# Altium schematic sheet ГРЛМ.468381.003 Э3.SchDoc
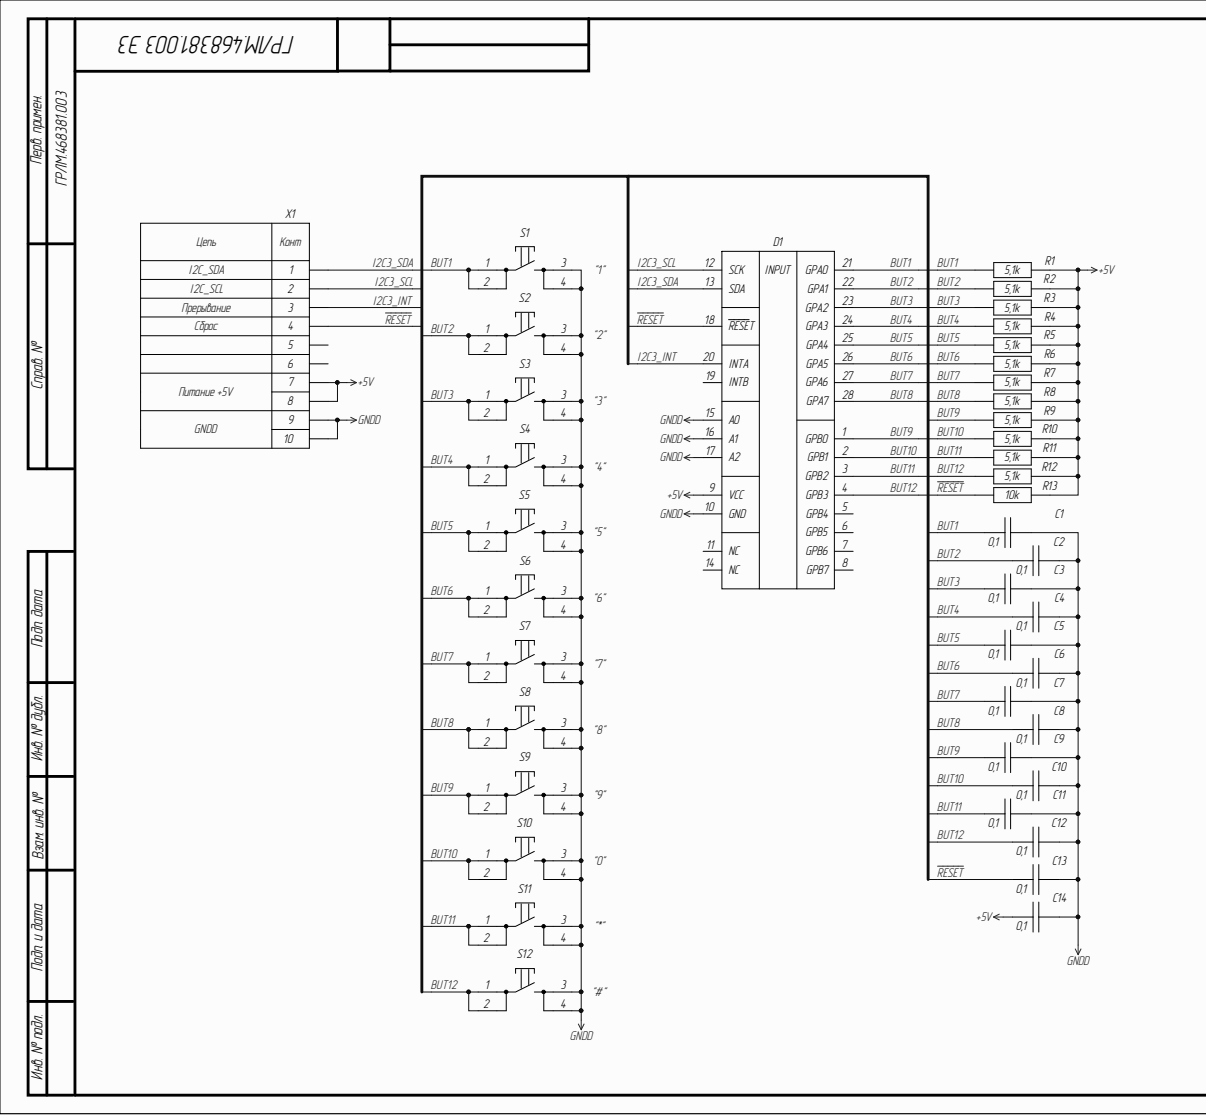
[diagram p0: ГРЛМ.468381.003 Э3.SchDoc, left]
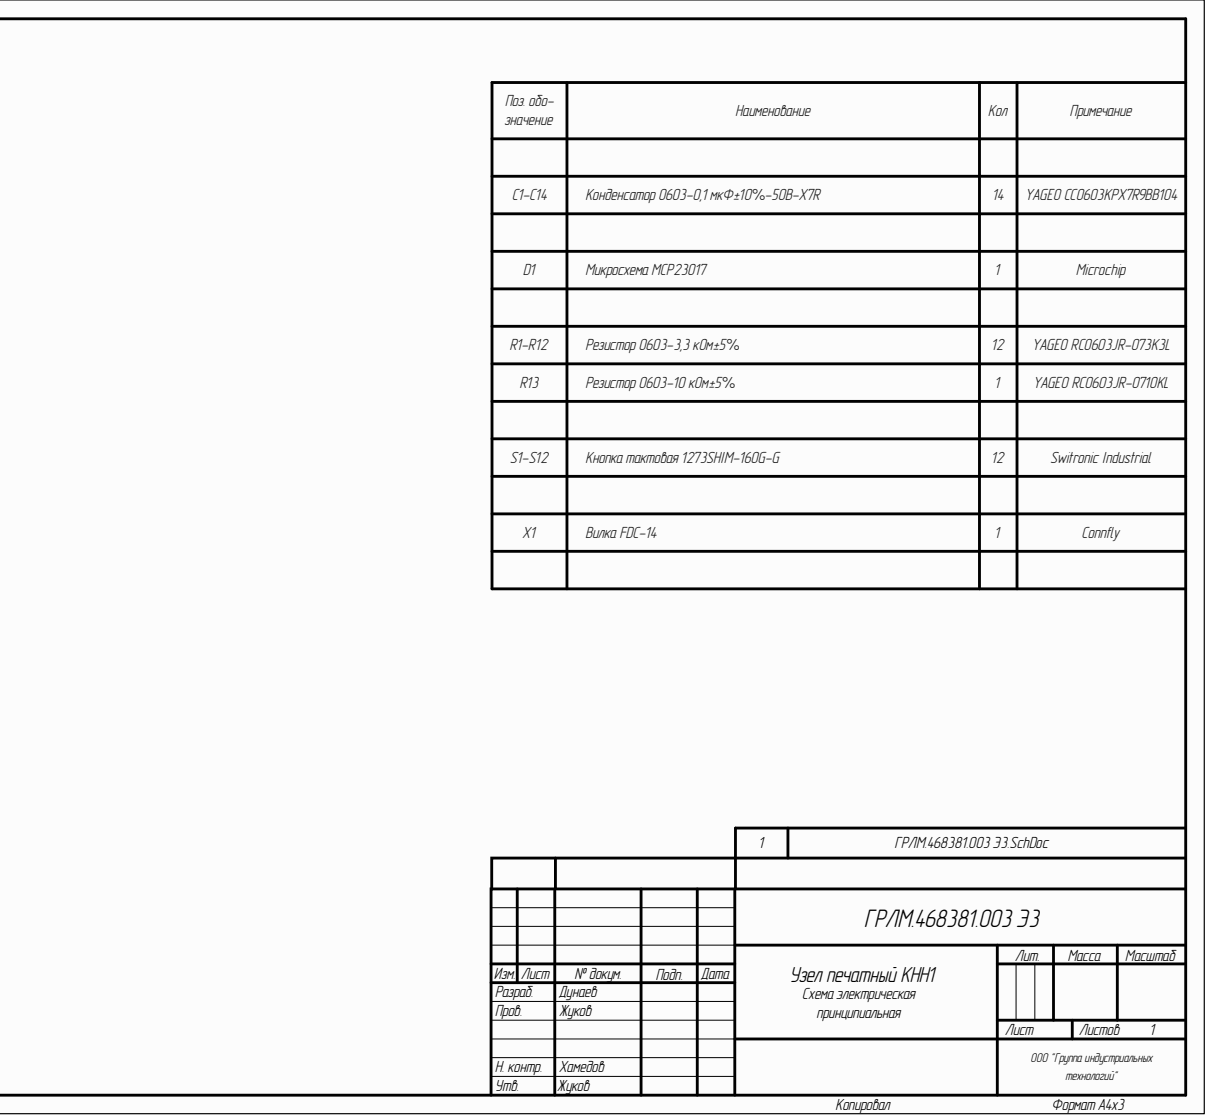
[diagram p1: ГРЛМ.468381.003 Э3.SchDoc, right]

## Parts
C1  value 0,1  [CAP0603]  (hidden comment: CAP_SMD_CER)
C2  value 0,1  [CAP0603]  (hidden comment: CAP_SMD_CER)
C3  value 0,1  [CAP0603]  (hidden comment: CAP_SMD_CER)
C4  value 0,1  [CAP0603]  (hidden comment: CAP_SMD_CER)
C5  value 0,1  [CAP0603]  (hidden comment: CAP_SMD_CER)
C6  value 0,1  [CAP0603]  (hidden comment: CAP_SMD_CER)
C7  value 0,1  [CAP0603]  (hidden comment: CAP_SMD_CER)
C8  value 0,1  [CAP0603]  (hidden comment: CAP_SMD_CER)
C9  value 0,1  [CAP0603]  (hidden comment: CAP_SMD_CER)
C10  value 0,1  [CAP0603]  (hidden comment: CAP_SMD_CER)
C11  value 0,1  [CAP0603]  (hidden comment: CAP_SMD_CER)
C12  value 0,1  [CAP0603]  (hidden comment: CAP_SMD_CER)
C13  value 0,1  [CAP0603]  (hidden comment: CAP_SMD_CER)
C14  value 0,1  [CAP0603]  (hidden comment: CAP_SMD_CER)
D1  MCP23017  [SOIC-28]
R1  value 5,1k  [RES0603]  (hidden comment: RES_SMD)
R2  value 5,1k  [RES0603]  (hidden comment: RES_SMD)
R3  value 5,1k  [RES0603]  (hidden comment: RES_SMD)
R4  value 5,1k  [RES0603]  (hidden comment: RES_SMD)
R5  value 5,1k  [RES0603]  (hidden comment: RES_SMD)
R6  value 5,1k  [RES0603]  (hidden comment: RES_SMD)
R7  value 5,1k  [RES0603]  (hidden comment: RES_SMD)
R8  value 5,1k  [RES0603]  (hidden comment: RES_SMD)
R9  value 5,1k  [RES0603]  (hidden comment: RES_SMD)
R10  value 5,1k  [RES0603]  (hidden comment: RES_SMD)
R11  value 5,1k  [RES0603]  (hidden comment: RES_SMD)
R12  value 5,1k  [RES0603]  (hidden comment: RES_SMD)
R13  value 10k  [RES0603]  (hidden comment: RES_SMD)
S1  1273SHIM-160G-G  [1273SHIM-160G-G]
S2  1273SHIM-160G-G  [1273SHIM-160G-G]
S3  1273SHIM-160G-G  [1273SHIM-160G-G]
S4  1273SHIM-160G-G  [1273SHIM-160G-G]
S5  1273SHIM-160G-G  [1273SHIM-160G-G]
S6  1273SHIM-160G-G  [1273SHIM-160G-G]
S7  1273SHIM-160G-G  [1273SHIM-160G-G]
S8  1273SHIM-160G-G  [1273SHIM-160G-G]
S9  1273SHIM-160G-G  [1273SHIM-160G-G]
S10  1273SHIM-160G-G  [1273SHIM-160G-G]
S11  1273SHIM-160G-G  [1273SHIM-160G-G]
S12  1273SHIM-160G-G  [1273SHIM-160G-G]
X1  FDC-10  [FDC-10]  (value not drawn)

## Nets
+5V: C14.1 D1.9(VCC) R1.2 R2.2 R3.2 R4.2 R5.2 R6.2 R7.2 R8.2 R9.2 R10.2 R11.2 R12.2 R13.2 X1.7 X1.8
GNDD: C1.2 C2.2 C3.2 C4.2 C5.2 C6.2 C7.2 C8.2 C9.2 C10.2 C11.2 C12.2 C13.2 C14.2 D1.10(GND) D1.15(A0) D1.16(A1) D1.17(A2) S1.3 S1.4 S2.3 S2.4 S3.3 S3.4 S4.3 S4.4 S5.3 S5.4 S6.3 S6.4 S7.3 S7.4 S8.3 S8.4 S9.3 S9.4 S10.3 S10.4 S11.3 S11.4 S12.3 S12.4 X1.9 X1.10
BUT1: C1.1 D1.21(GPA0) R1.1 S1.1 S1.2
BUT2: C2.1 D1.22(GPA1) R2.1 S2.1 S2.2
BUT3: C3.1 D1.23(GPA2) R3.1 S3.1 S3.2
BUT4: C4.1 D1.24(GPA3) R4.1 S4.1 S4.2
BUT5: C5.1 D1.25(GPA4) R5.1 S5.1 S5.2
BUT6: C6.1 D1.26(GPA5) R6.1 S6.1 S6.2
BUT7: C7.1 D1.27(GPA6) R7.1 S7.1 S7.2
BUT8: C8.1 D1.28(GPA7) R8.1 S8.1 S8.2
BUT9: C9.1 D1.1(GPB0) R9.1 S9.1 S9.2
BUT10: C10.1 D1.2(GPB1) R10.1 S10.1 S10.2
BUT11: C11.1 D1.3(GPB2) R11.1 S11.1 S11.2
BUT12: C12.1 D1.4(GPB3) R12.1 S12.1 S12.2
I2C3_INT: D1.20(INTA) X1.3
I2C3_SCL: D1.12(SCK) X1.2
I2C3_SDA: D1.13(SDA) X1.1
~{RESET}: C13.1 D1.18(RESET) R13.1 X1.4
N00018:

Not connected: D1 5(GPB4) 6(GPB5) 7(GPB6) 8(GPB7) 11(NC) 14(NC) 19(INTB); X1 5 6

## Library data of the parts
C1-C14 = CAP_SMD_CER: Конденсатор керамический; Manufacturer: YAGEO; Tolerance: 10%; Type: 0603-0,1 мкФ±10%-50В-X7R; Vendor code: CC0603KPX7R9BB104; Case size: 0603; Component Kind: Standard; Library Name: Capacitors.IntLib; Simulation: CAP_SMD_CER; Temperature range: -55...125
D1 = MCP23017: Расширитель порта; Manufacturer: Microchip; Case size: SOIC-28; Component Kind: Standard; Library Name: Integrated circuits.IntLib; Temperature range: -40...125; Vendor code: MCP23017
R1-R12 = RES_SMD: Резистор SMD; Manufacturer: YAGEO; Tolerance: 5%; Vendor code: RC0603JR-075K1L; Case size: 0603; Component Kind: Standard; Library Name: Resistors.IntLib; Simulation: RES_SMD; Temperature range: -55...155; Type: 0603-5,1 кОм±5%
R13 = RES_SMD: Резистор SMD; Manufacturer: YAGEO; Tolerance: 5%; Vendor code: RC0603JR-0710KL; Case size: 0603; Component Kind: Standard; Library Name: Resistors.IntLib; Simulation: RES_SMD; Temperature range: -55...155; Type: 0603-10 кОм±5%
S1-S12 = 1273SHIM-160G-G: Кнопка тактовая; Manufacturer: Switronic; Component Kind: Standard; Library Name: Switching devices.IntLib; Vendor code: 1273SHIM-160G-G
X1 = FDC-10: Вилка; Manufacturer: Connfly; Component Kind: Standard; Library Name: Connectors.IntLib; Temperature range: -40...105; Vendor code: FDC-10
